# Altium schematic sheet GPS.SchDoc(GPS)
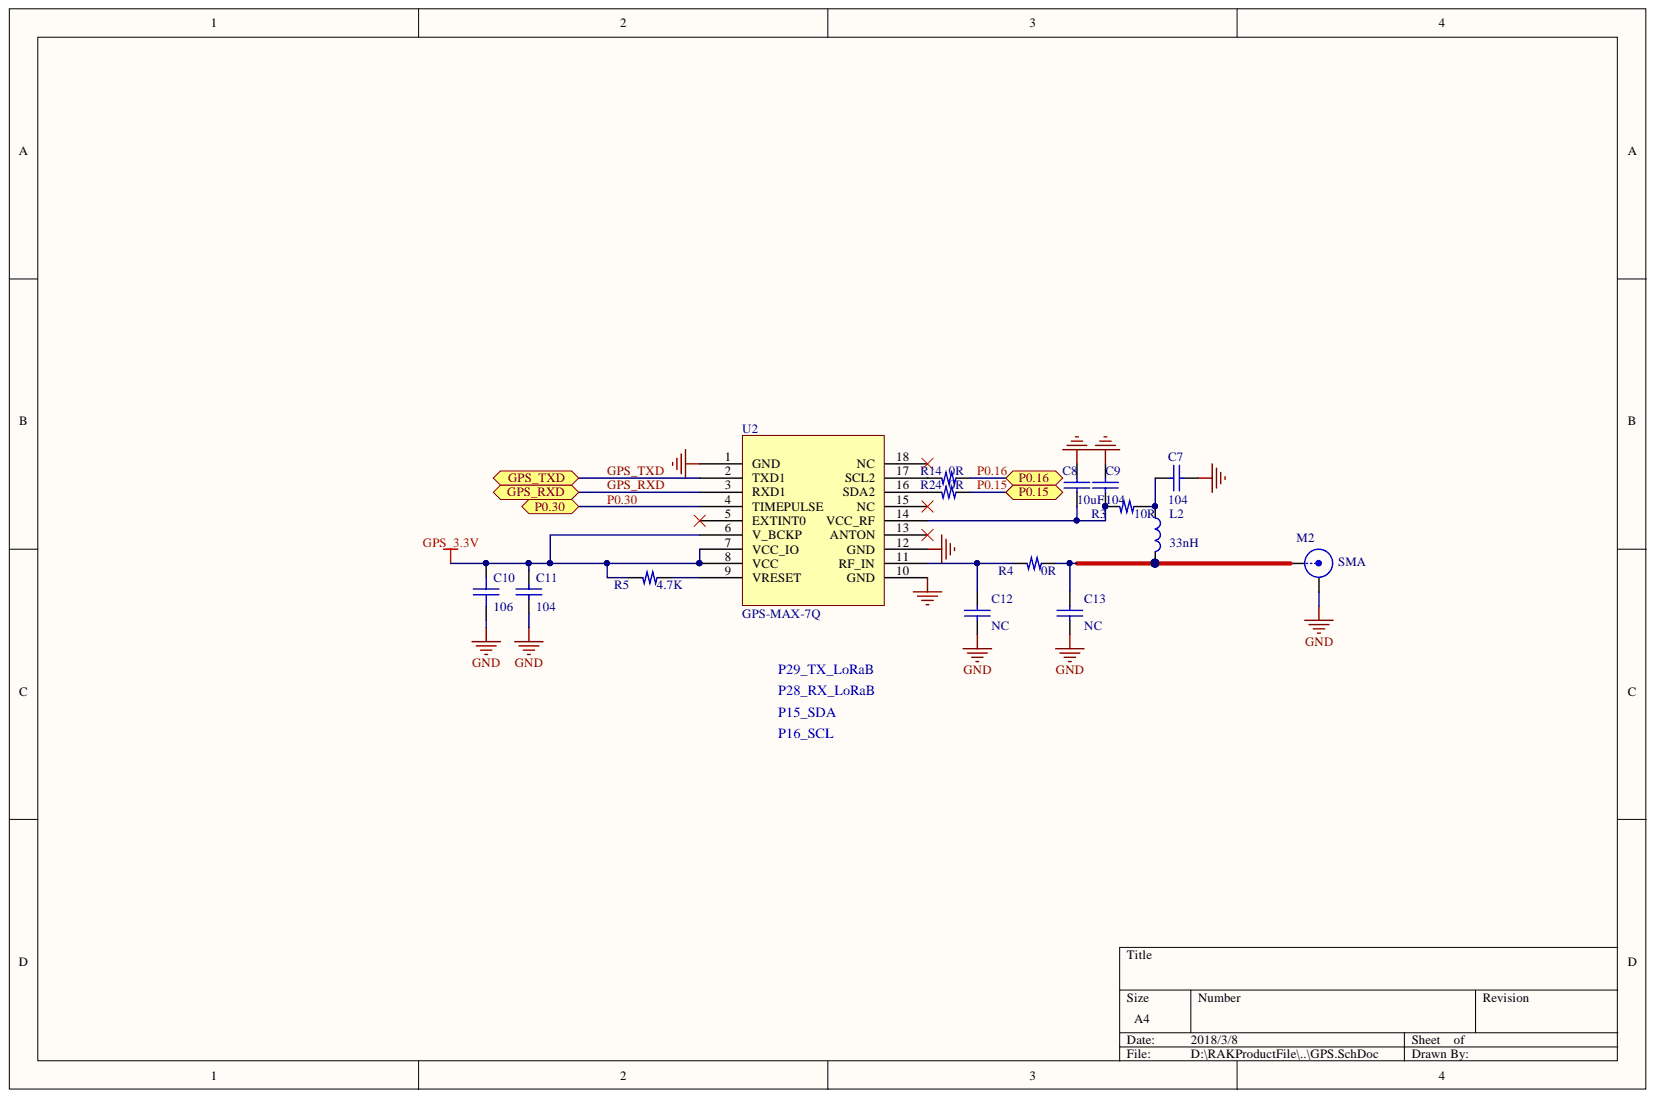
[diagram p0: GPS.SchDoc]

## Parts
C7  104  [CAPC1005X04L]
C8  10uF  [CAPC1608X06L]
C9  104  [CAPC1005X04L]
C10  106  [CAPC1608X06L]
C11  104  [CAPC1005X04L]
C12  NC  [CAPC1005X04L]
C13  NC  [CAPC1005X04L]
L2  33nH  [CAPC1005X04L]
M2  SMA  [SMA END LAUNCH JACK RECEPTACLE SHORT]
R3  10R  [CAPC1005X04L]
R4  0R  [CAPC1005X04L]
R5  4.7K  [CAPC1005X04L]
R14  0R  [CAPC1005X04L]
R24  0R  [CAPC1005X04L]
U2  GPS-MAX-7Q  [MAX-6Q]

## Ports
GPS_RXD, GPS_TXD, P0.15, P0.16, P0.30

## Nets
GND: C7.2 C8.2 C9.2 C10.1 C11.2 C12.1 C13.1 M2.2 U2.1(GND) U2.10(GND) U2.12(GND)
GPS_3.3V: C10.2 C11.1 R5.2 U2.6(V_BCKP) U2.7(VCC_IO) U2.8(VCC)
GPS_RXD: U2.3(RXD1) ; port GPS_RXD
GPS_TXD: U2.2(TXD1) ; port GPS_TXD
P0.15: R24.1 ; port P0.15
P0.16: R14.1 ; port P0.16
P0.30: U2.4(TIMEPULSE) ; port P0.30
NetC7_1: C7.1 L2.1 R3.1
NetC8_1: C8.1 C9.1 R3.2 U2.14(VCC_RF)
NetC12_2: C12.2 R4.2 U2.11(RF_IN)
NetC13_2: C13.2 L2.2 M2.1 R4.1
NetR5_1: R5.1 U2.9(VRESET)
NetR14_2: R14.2 U2.17(SCL2)
NetR24_2: R24.2 U2.16(SDA2)

Not connected: U2 5(EXTINT0) 13(ANTON) 15(NC) 18(NC)

## Library data of the parts
C7 C9 C11-C13 = CAP: CAP-MLCC,CL05B104KO5NNNC,104,10%,X7R,16V,0402,SAMSUNG; Component Kind: Standard
C8 = CAP: CAP-MLCC,CL31A106KAHNNNE,106,-10+90%,X5R,25V,1206,SAMSUNG; Component Kind: Standard
C10 = 1.02.02.0055: CAP-MLCC,CL31A106KAHNNNE,106,-10+90%,X5R,25V,1206,SAMSUNG; Database Table Name: VErpDataInfo; Value: 106,10μF; 名称: 电容; 型号: 106,10μF,±10%,10V,X5R; 封装: 0805; 设备封装名称: C0805_106_X5R_10V_10%; 贴片和直插: SMT; Component Kind: Standard; ComponentLink1Description: ErpDataInfo; ComponentLink1URL: http://erp.zlgmcu.com/ErpExt/ErpData/ListMateriel.aspx?pkey=1.02.02.0055; Library Name: ErpMaterialData.DbLib; RoHS: RoHS; 物料代码: 1.02.02.0055
L2 = INDUCTOR: Component Kind: Standard; Description: INDUCTOR
M2 = SMA: SMA Straight Connector; Component Kind: Standard; LatestRevisionDate: 05-oct-2007  -  Semtech; LatestRevisionNote: Re-released for DXP Platform.; Library Name: SX1276_e266v02a.SchLib; Publisher: Altium Limited
R3-R5 R14 R24 = 电阻: Component Kind: Standard
U2 = MAX-6Q: GPS模块; Component Kind: Standard
